# Altium schematic sheet Sheet4.SchDoc
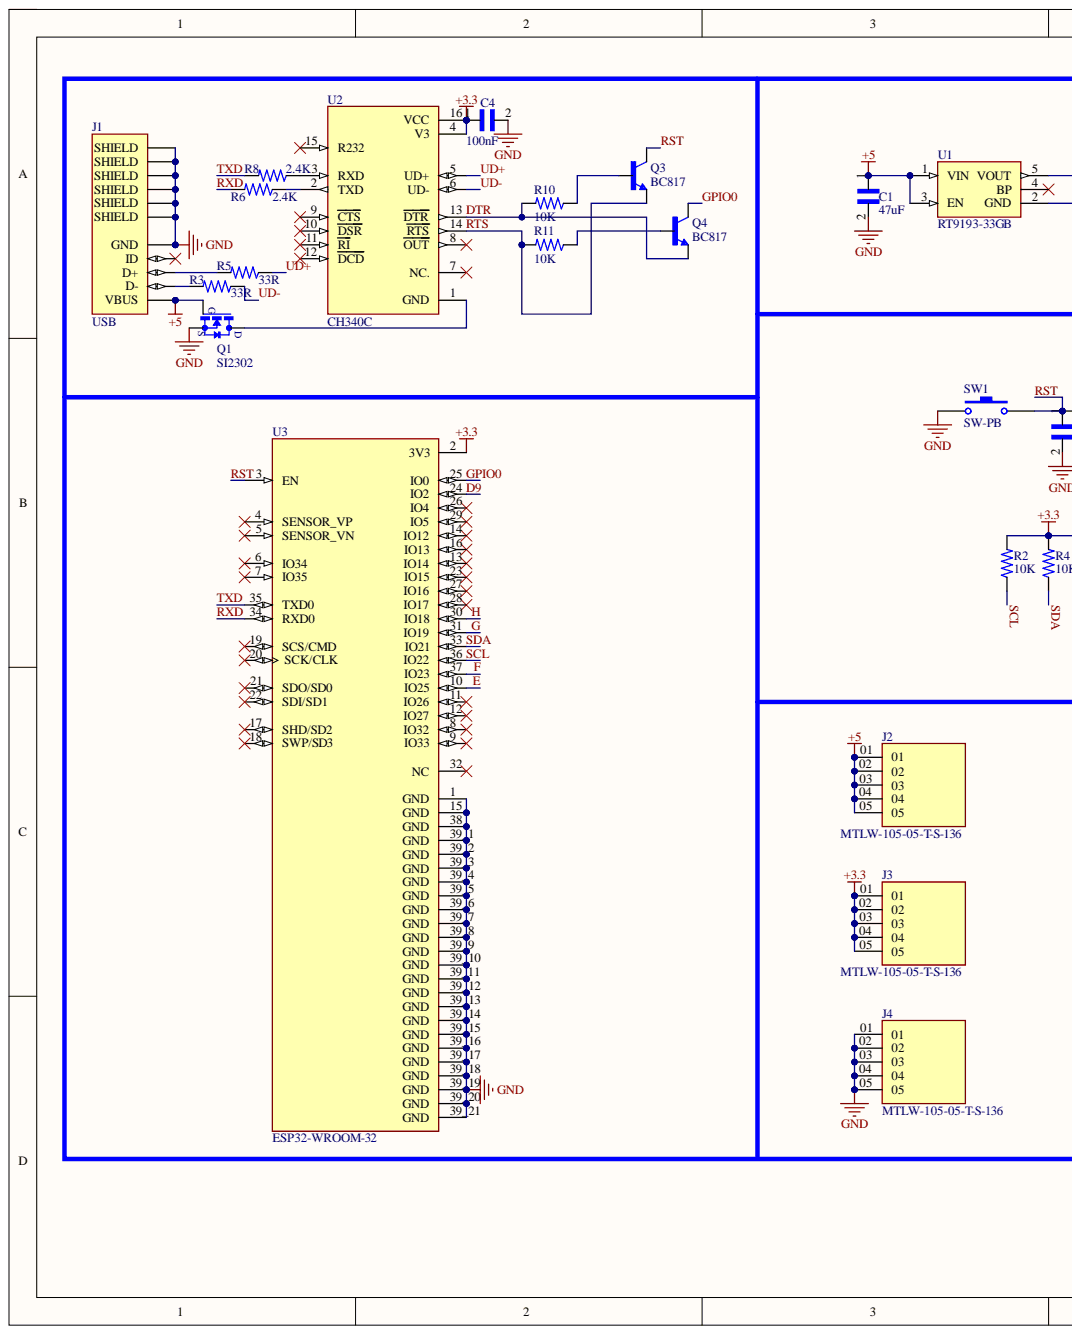
[diagram p0: Sheet4.SchDoc, left]
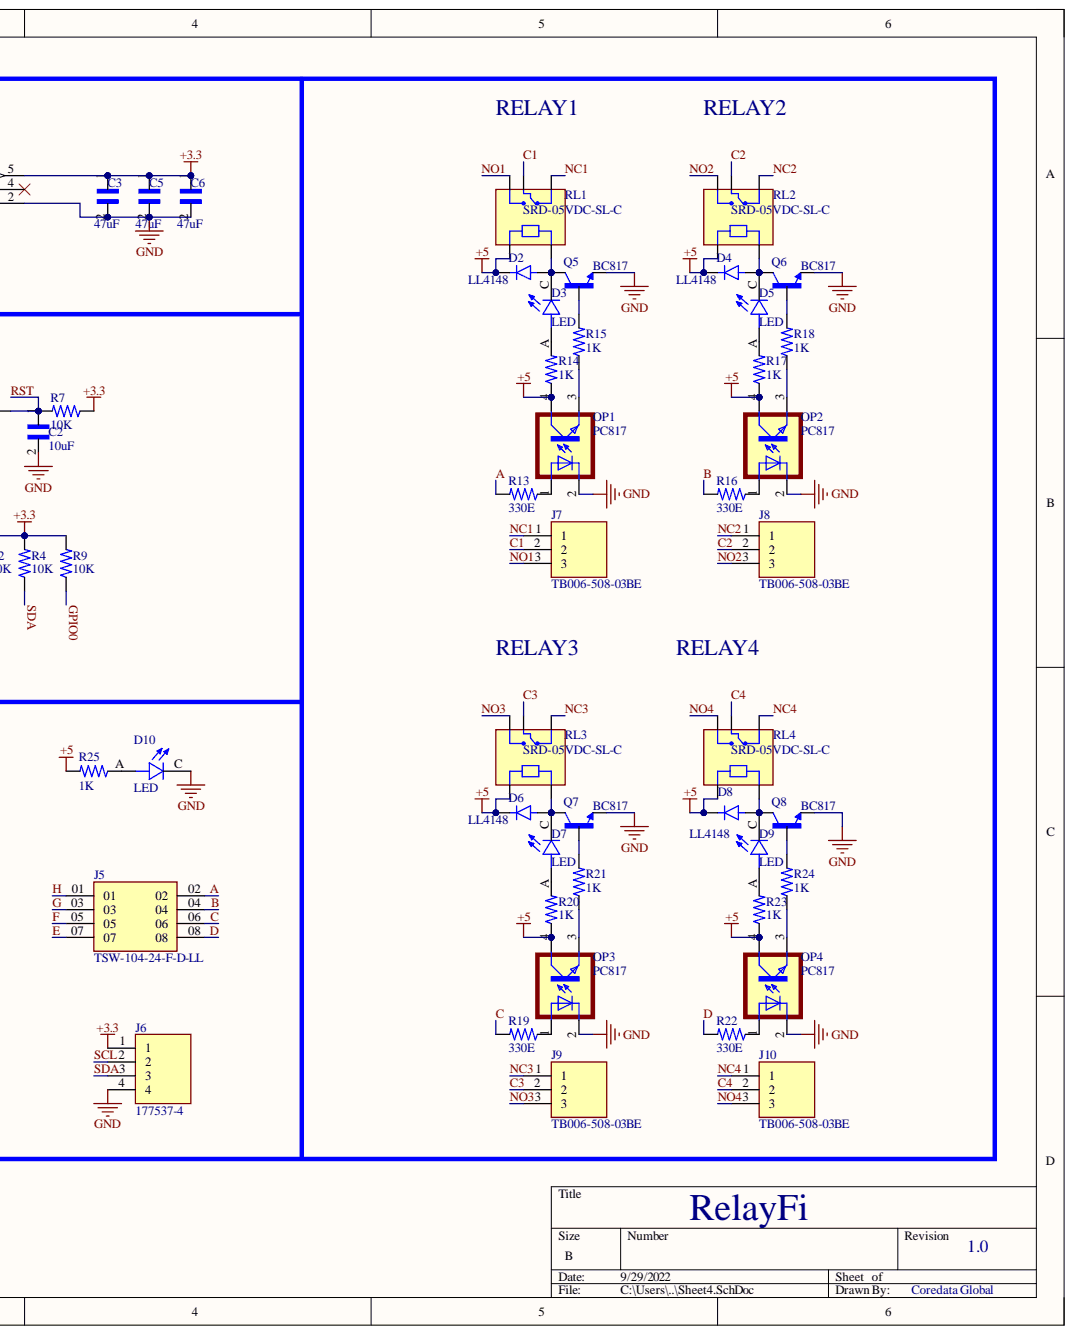
[diagram p1: Sheet4.SchDoc, right]

## Parts
C1  47uF  [CAPC1608X90]
C2  10uF  [CAPC1608X90]
C3  47uF  [CAPC1608X90]
C4  100nF  [CAPC1608X90]
C5  47uF  [CAPC1608X90]
C6  47uF  [CAPC1608X90]
D2  LL4148  [DIO_LL4148]
D3  LED  [LED_SML-D12M1WT86]
D4  LL4148  [DIO_LL4148]
D5  LED  [LED_SML-D12M1WT86]
D6  LL4148  [DIO_LL4148]
D7  LED  [LED_SML-D12M1WT86]
D8  LL4148  [DIO_LL4148]
D9  LED  [LED_SML-D12M1WT86]
D10  LED  [LED_SML-D12M1WT86]
J1  USB  [GCT_USB3076-XX-X_REVC]
J2  MTLW-105-05-T-S-136  [SAMTEC_MTLW-105-05-T-S-136]
J3  MTLW-105-05-T-S-136  [SAMTEC_MTLW-105-05-T-S-136]
J4  MTLW-105-05-T-S-136  [SAMTEC_MTLW-105-05-T-S-136]
J5  TSW-104-24-F-D-LL  [SAMTEC_TSW-104-24-F-D-LL]
J6  177537-4  [TE_177537-4]
J7  TB006-508-03BE  [CUI_TB006-508-03BE]
J8  TB006-508-03BE  [CUI_TB006-508-03BE]
J9  TB006-508-03BE  [CUI_TB006-508-03BE]
J10  TB006-508-03BE  [CUI_TB006-508-03BE]
OP1  PC817  [DIL04]
OP2  PC817  [DIL04]
OP3  PC817  [DIL04]
OP4  PC817  [DIL04]
Q1  SI2302  [SOT23]
Q3  BC817  [SOT95P230X110-3N]
Q4  BC817  [SOT95P230X110-3N]
Q5  BC817  [SOT95P230X110-3N]
Q6  BC817  [SOT95P230X110-3N]
Q7  BC817  [SOT95P230X110-3N]
Q8  BC817  [SOT95P230X110-3N]
R2  10K  [RESC1608X50N]
R3  33R  [RESC1608X50N]
R4  10K  [RESC1608X50N]
R5  33R  [RESC1608X50N]
R6  2.4K  [RESC1608X50N]
R7  10K  [RESC1608X50N]
R8  2.4K  [RESC1608X50N]
R9  10K  [RESC1608X50N]
R10  10K  [RESC1608X50N]
R11  10K  [RESC1608X50N]
R13  330E  [RESC1608X50N]
R14  1K  [RESC1608X50N]
R15  1K  [RESC1608X50N]
R16  330E  [RESC1608X50N]
R17  1K  [RESC1608X50N]
R18  1K  [RESC1608X50N]
R19  330E  [RESC1608X50N]
R20  1K  [RESC1608X50N]
R21  1K  [RESC1608X50N]
R22  330E  [RESC1608X50N]
R23  1K  [RESC1608X50N]
R24  1K  [RESC1608X50N]
R25  1K  [RESC1608X50N]
RL1  SRD-05VDC-SL-C  [RELAY_SRD-05VDC-SL-C]
RL2  SRD-05VDC-SL-C  [RELAY_SRD-05VDC-SL-C]
RL3  SRD-05VDC-SL-C  [RELAY_SRD-05VDC-SL-C]
RL4  SRD-05VDC-SL-C  [RELAY_SRD-05VDC-SL-C]
SW1  SW-PB  [SWSMD2PIN]
U1  RT9193-33GB  [SOT94P279X129-5N]
U2  CH340C  [SOIC127P600X180-16N]
U3  ESP32-WROOM-32  [MODULE_ESP32-WROOM-32]

## Nets
+3.3: C3.1 C4.1 C5.1 C6.1 J3.01 J3.02 J3.03 J3.04 J3.05 J6.1 R2.2 R4.2 R7.1 R9.2 U1.5(VOUT) U2.4(V3) U2.16(VCC) U3.2(3V3)
+5: C1.1 D2.C D4.C D6.C D8.C J1.1(VBUS) J2.01 J2.02 J2.03 J2.04 J2.05 OP1.4 OP2.4 OP3.4 OP4.4 Q1.1 R14.1 R17.1 R20.1 R23.1 R25.2 RL1.A1 RL2.A1 RL3.A1 RL4.A1 U1.1(VIN) U1.3(EN)
GND: C1.2 C2.2 C3.2 C4.2 C5.2 C6.2 D10.C J1.5(GND) J1.6(SHIELD) J1.7(SHIELD) J1.8(SHIELD) J1.9(SHIELD) J1.10(SHIELD) J1.11(SHIELD) J4.01 J4.02 J4.03 J4.04 J4.05 J6.4 OP1.2 OP2.2 OP3.2 OP4.2 Q1.2 Q5.2 Q6.2 Q7.2 Q8.2 SW1.1 U1.2(GND) U3.1(GND) U3.15(GND) U3.38(GND) U3.39_1(GND) U3.39_2(GND) U3.39_3(GND) U3.39_4(GND) U3.39_5(GND) U3.39_6(GND) U3.39_7(GND) U3.39_8(GND) U3.39_9(GND) U3.39_10(GND) U3.39_11(GND) U3.39_12(GND) U3.39_13(GND) U3.39_14(GND) U3.39_15(GND) U3.39_16(GND) U3.39_17(GND) U3.39_18(GND) U3.39_19(GND) U3.39_20(GND) U3.39_21(GND)
A: J5.02 R13.2
B: J5.04 R16.2
C: J5.06 R19.2
C1: J7.2 RL1.COM
C2: J8.2 RL2.COM
C3: J9.2 RL3.COM
C4: J10.2 RL4.COM
D: J5.08 R22.2
D9: U3.24(IO2)
DTR: Q4.2 R10.2 U2.13(DTR)
E: J5.07 U3.10(IO25)
F: J5.05 U3.37(IO23)
G: J5.03 U3.31(IO19)
GPIO0: Q4.3 R9.1 U3.25(IO0)
H: J5.01 U3.30(IO18)
NC1: J7.1 RL1.NC
NC2: J8.1 RL2.NC
NC3: J9.1 RL3.NC
NC4: J10.1 RL4.NC
NO1: J7.3 RL1.NO
NO2: J8.3 RL2.NO
NO3: J9.3 RL3.NO
NO4: J10.3 RL4.NO
RST: C2.1 Q3.3 R7.2 SW1.2 U3.3(EN)
RTS: Q3.2 R11.2 U2.14(RTS)
RXD: R6.2 U3.34(RXD0)
SCL: J6.2 R2.1 U3.36(IO22)
SDA: J6.3 R4.1 U3.33(IO21)
TXD: R8.2 U3.35(TXD0)
UD+: R5.1 U2.5(UD+)
UD-: R3.1 U2.6(UD-)
NetD2_A: D2.A D3.C Q5.3 RL1.A2
NetD3_A: D3.A R14.2
NetD4_A: D4.A D5.C Q6.3 RL2.A2
NetD5_A: D5.A R17.2
NetD6_A: D6.A D7.C Q7.3 RL3.A2
NetD7_A: D7.A R20.2
NetD8_A: D8.A D9.C Q8.3 RL4.A2
NetD9_A: D9.A R23.2
NetD10_A: D10.A R25.1
NetJ1_2: J1.2(D-) R3.2
NetJ1_3: J1.3(D+) R5.2
NetOP1_1: OP1.1 R13.1
NetOP1_3: OP1.3 R15.1
NetOP2_1: OP2.1 R16.1
NetOP2_3: OP2.3 R18.1
NetOP3_1: OP3.1 R19.1
NetOP3_3: OP3.3 R21.1
NetOP4_1: OP4.1 R22.1
NetOP4_3: OP4.3 R24.1
NetQ1_3: Q1.3 U2.1(GND)
NetQ3_1: Q3.1 R10.1
NetQ4_1: Q4.1 R11.1
NetQ5_1: Q5.1 R15.2
NetQ6_1: Q6.1 R18.2
NetQ7_1: Q7.1 R21.2
NetQ8_1: Q8.1 R24.2
NetR6_1: R6.1 U2.2(TXD)
NetR8_1: R8.1 U2.3(RXD)

Not connected: J1 4(ID); U1 4(BP); U2 7(NC.) 8(OUT) 9(CTS) 10(DSR) 11(RI) 12(DCD) 15(R232); U3 4(SENSOR_VP) 5(SENSOR_VN) 6(IO34) 7(IO35) 8(IO32) 9(IO33) 11(IO26) 12(IO27) 13(IO14) 14(IO12) 16(IO13) 17(SHD/SD2) 18(SWP/SD3) 19(SCS/CMD) 20(SCK/CLK) 21(SDO/SD0) 22(SDI/SD1) 23(IO15) 26(IO4) 27(IO16) 28(IO17) 29(IO5) 32(NC)

## Library data of the parts
C1-C6 = LD035C181JAB2A: CAP CER SMD; MF: AVX; Availability: Unavailable; Component Kind: Standard; Library Name: LD035C181JAB2A.IntLib; MP: LD035C181JAB2A; Package: 0603 AVX; Price: None; SnapEDA_Link: https://www.snapeda.com/parts/LD035C181JAB2A/AVX/view-part/2034489/?ref=snap
D2 D4 D6 D8 = LL4148: Diode Standard 100V 200mA Surface Mount SOD-80; MF: ON Semiconductor; Availability: Unavailable; Component Kind: Standard; Library Name: LL4148.IntLib; MP: LL4148; Package: SOD-80 Taitron; Price: None; SnapEDA_Link: https://www.snapeda.com/parts/LL4148/ON%20Semiconductor/view-part/2182678/?ref=snap
D3 D5 D7 D9 D10 = SML-D12M1WT86: Yellow-Green 572nm LED Indication - Discrete 2.2V 0603 (1608 Metric); MF: Rohm; Check_prices: https://www.snapeda.com/parts/SML-D12M1WT86/Rohm/view-part/584322/?ref=eda; Component Kind: Standard; Library Name: SML-D12M1WT86.IntLib; MP: SML-D12M1WT86; Package: 0603 Rohm; Purchase-URL: https://pricing.snapeda.com/search/part/SML-D12M1WT86/?ref=eda; SnapEDA_Link: https://www.snapeda.com/parts/SML-D12M1WT86/Rohm/view-part/584322/?ref=snap
J1 = USB3076-XX-X_REVC: Micro USB Receptacle, Type B, SMT, Horizontal, Bottom Mount w/out Peg, w/out Shell Stakes, w/out Mating Face Lead-In; Availability: Unavailable; Component Kind: Standard; Library Name: USB3076-XX-X_REVC.IntLib; MP: USB3076-XX-X_REVC; Package: None; Price: None
J6 = 177537-4: 2.5 M.I.S POST HDR ASSY 4P; Centerline_Pitch: 2.5 mm[.098 in]; MF: TE Connectivity; Number_of_Positions: 4; Product_Type: Connector; Component Kind: Standard; Digi-Key_Part_Number: 177537-4-ND; Digi-Key_Purchase_URL: https://www.digikey.com.cn/product-detail/zh/te-connectivity-amp-connectors/177537-4/177537-4-ND/2187850?utm_source=snapeda&utm_medium=aggregator&utm_campaign=symbol; EU_RoHS_Compliance: Compliant; Library Name: 177537-4.IntLib; MP: 177537-4; Package: None; SnapEDA_Link: https://www.snapeda.com/parts/177537-4/TE%20Connectivity%20AMP%20Connectors/view-part/1403050/?ref=snap; TE_purchase_URL: https://www.te.com/usa-en/product-177537-4.html?te_bu=Cor&te_type=disp&te_campaign=seda_glo_cor-seda-global-disp-prtnr-fy19-seda-model-bom-cta_sma-317_1&elqCampaignId=32493
J7-J10 = TB006-508-03BE: 2~24 Poles, Screw Type, Horizontal, 5.08 Pitch, 26~14 _AWG_, Terminal Block Connector; MF: CUI Devices; Availability: Unavailable; Component Kind: Standard; CUI_purchase_URL: https://www.cuidevices.com/product/interconnect/connectors/terminal-blocks/tb006-508-series?utm_source=snapeda.com&utm_medium=referral&utm_campaign=snapedaBOM; Library Name: TB006-508-03BE.IntLib; MP: TB006-508-03BE; Package: None; Price: None; SnapEDA_Link: https://www.snapeda.com/parts/TB006-508-03BE/CUI%20Devices/view-part/3591102/?ref=snap
OP1-OP4 = PC817: RF Mixer IC Cellular, DCS, PCS, W-CDMA, WLAN Up Converter 50MHz ~ 400MHz 6-SuperMiniMold; MF: Renesas Electronics America Inc; Availability: In Stock; Check_prices: https://www.snapeda.com/parts/PC817/Renesas/view-part/6617977/?ref=eda; Component Kind: Standard; Library Name: PC817.IntLib; MP: PC817; Package: None; Price: None; Purchase-URL: https://pricing.snapeda.com/search/part/PC817/?ref=eda; SnapEDA_Link: https://www.snapeda.com/parts/PC817/Renesas/view-part/6617977/?ref=snap
Q1 = SI2302: N-Channel 20V 2.6A _Ta_ 710mW _Ta_ Surface Mount SOT-23-3 _TO-236_; MF: Vishay Siliconix; Availability: Unavailable; Component Kind: Standard; Library Name: SI2302.IntLib; MP: SI2302; Package: SOT-23-3 Vishay Siliconix; Price: None; SnapEDA_Link: https://www.snapeda.com/parts/SI2302/Vishay%20Siliconix/view-part/2777527/?ref=snap
Q3-Q8 = BC817: Bipolar _BJT_ Transistor NPN 45V 500mA 100MHz 300mW Surface Mount SOT-23-3 _TO-236_; MF: Nexperia USA; Availability: Unavailable; Component Kind: Standard; Library Name: BC817.IntLib; MP: BC817; Package: SOT-23-3 Rectron; Price: None; SnapEDA_Link: https://www.snapeda.com/parts/BC817/Nexperia%20USA%20Inc./view-part/4219270/?ref=snap
R2-R11 R13-R25 = 2176051-9: RES 0.11 OHM 1% 1/8W 0603; MF: TE Connectivity; Power_Rating: .125 W; Resistance: .11; Availability: Unavailable; Component Kind: Standard; EU_RoHS_Compliance: Compliant with Exemptions; Library Name: 2176051-9.IntLib; MP: 2176051-9; Package: 0603; Price: None; SnapEDA_Link: https://www.snapeda.com/parts/2176051-9/TE%20Connectivity/view-part/2213262/?ref=snap; Tolerance: 1%
RL1-RL4 = SRD-05VDC-SL-C: 5V Trigger Relay Module For Arduino And Raspberry Pi 5V Trigger Relay Module For Arduino And Raspberry Pi; MF: Songle Relay; Availability: Not in stock; Check_prices: https://www.snapeda.com/parts/SRD-05VDC-SL-C/Songle+Relay/view-part/?ref=eda; Component Kind: Standard; Library Name: SRD-05VDC-SL-C.IntLib; MP: SRD-05VDC-SL-C; Package: None; Price: None; SnapEDA_Link: https://www.snapeda.com/parts/SRD-05VDC-SL-C/Songle+Relay/view-part/?ref=snap
SW1 = SW-PB: Switch; Component Kind: Standard; LatestRevisionDate: 17-Jul-2002; LatestRevisionNote: Re-released for DXP Platform.; Library Name: Miscellaneous Devices.IntLib; PackageDescription: Switch; 2 Leads; PackageReference: SPST-2; Published: 24-Mar-1999; Publisher: Altium Limited
U1 = RT9193-33GB: Linear Voltage Regulator IC 1 Output 300mA SOT-23-5; MF: Richtek USA; Availability: Unavailable; Component Kind: Standard; Library Name: RT9193-33GB.IntLib; MP: RT9193-33GB; Package: SOT-23-5 Richtek; Price: None; SnapEDA_Link: https://www.snapeda.com/parts/RT9193-33GB/Richtek%20USA%20Inc./view-part/55570/?ref=snap
U2 = CH340C: USB to serial chip CH340; Availability: Unavailable; Component Kind: Standard; Library Name: CH340C (2).IntLib; MP: CH340C; Package: None; Price: None
J2-J4 = MTLW-105-05-T-S-136: Availability: Unavailable; Component Kind: Standard; Library Name: MTLW-105-05-T-S-136.IntLib; MP: MTLW-105-05-T-S-136; Package: None; Price: None
J5 = TSW-104-24-F-D-LL: Availability: Unavailable; Component Kind: Standard; Library Name: TSW-104-24-F-D-LL.IntLib; MP: TSW-104-24-F-D-LL; Package: None; Price: None
U3 = ESP32-WROOM-32: Availability: Unavailable; Component Kind: Standard; Library Name: ESP32-WROOM-32.IntLib; MP: ESP32-WROOM-32; Package: None; Price: None
